# Altium schematic sheet SCH_HT-CT62.SchDoc
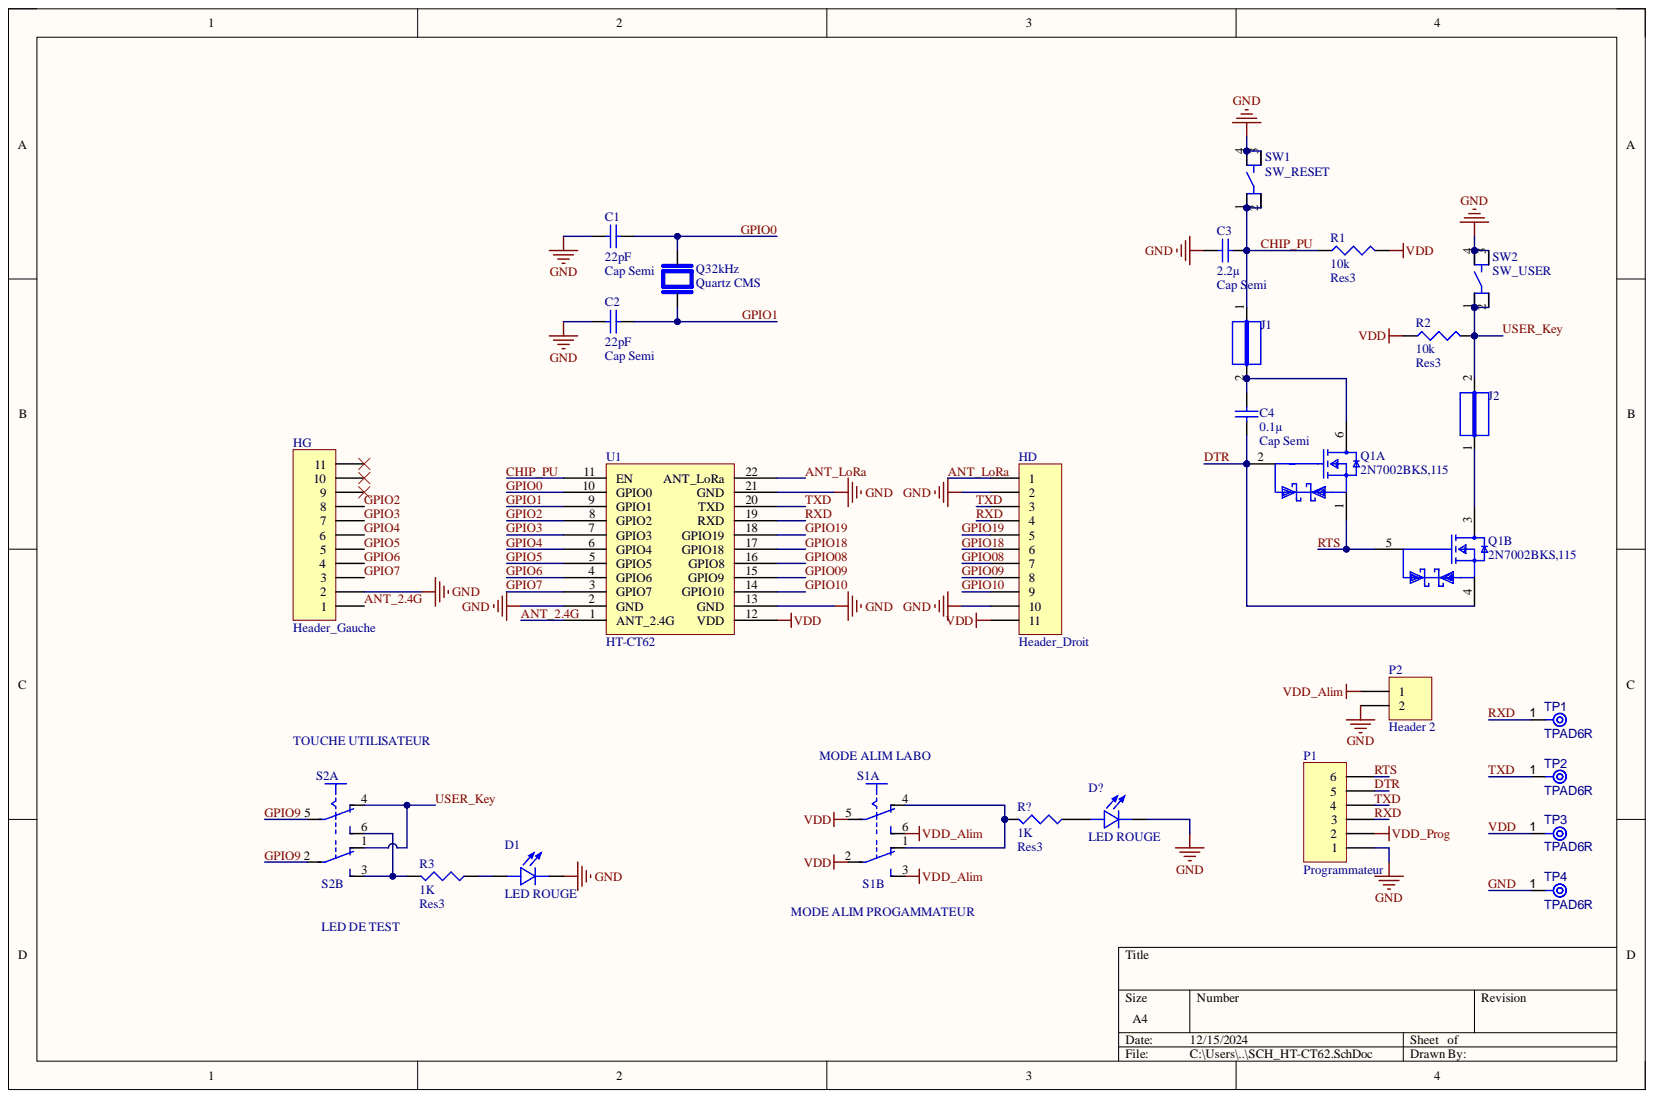
[diagram p0: SCH_HT-CT62.SchDoc]

## Parts
C1  Cap Semi  value 22pF  [1608[0603]]
C2  Cap Semi  value 22pF  [1608[0603]]
C3  Cap Semi  value 2.2µ  [1608[0603]]
C4  Cap Semi  value 0.1µ  [1608[0603]]
D1  LED ROUGE  [LED_KP-1608SURCK]
D?  LED ROUGE  [LED_KP-1608SURCK]
HD  Header_Droit  [HDR1X11]
HG  Header_Gauche  [HDR1X11]
J1  JUMPER  [JUMPER]  (value not drawn)
J2  JUMPER  [JUMPER]  (value not drawn)
P1  Programmateur  [HDR1X6]
P2  Header 2  [HDR1X2]
Q1  2N7002BKS,115  [FP-SOT363-IPC_C]  (parts A,B)
Q32kHz  Quartz CMS  [XTAL_ABS07-32.768KHZ-6-T]
R1  Res3  value 10k  [J1-0603]
R2  Res3  value 10k  [J1-0603]
R3  Res3  value 1K  [J1-0603]
R?  Res3  value 1K  [J1-0603]
S1  MODE ALIM LABO  [JS202011CQN]  (parts A,B)
S2  TOUCHE UTILISATEUR  [JS202011CQN]  (parts A,B)
SW1  SW_RESET  [SW_PTS810_SJM_250_SMTR_LFS]
SW2  SW_USER  [SW_PTS810_SJM_250_SMTR_LFS]
TP1  TPAD6R  [TP06R]  (no BOM)
TP2  TPAD6R  [TP06R]  (no BOM)
TP3  TPAD6R  [TP06R]  (no BOM)
TP4  TPAD6R  [TP06R]  (no BOM)
U1  HT-CT62  [HTCC-AM01]

## Nets
VDD_Alim: P2.1 S1.3 S1.6
VDD_Prog: P1.2
ANT_2.4G: HG.1 U1.1(ANT_2.4G)
ANT_LoRa: HD.1 U1.22(ANT_LoRa)
CHIP_PU: C3.2 J1.1 R1.1 SW1.1 SW1.2 U1.11(EN)
DTR: C4.1 P1.5 Q1.2 Q1.4
GND: C1.1 C2.1 C3.1 D1.2 D?.2 HD.2 HD.10 HG.2 P1.1 P2.2 SW1.3 SW1.4 SW2.3 SW2.4 TP4.1 U1.2(GND) U1.13(GND) U1.21(GND)
GPIO0: C1.2 Q32kHz.2 U1.10(GPIO0)
GPIO1: C2.2 Q32kHz.1 U1.9(GPIO1)
GPIO2: HG.8 U1.8(GPIO2)
GPIO3: HG.7 U1.7(GPIO3)
GPIO4: HG.6 U1.6(GPIO4)
GPIO5: HG.5 U1.5(GPIO5)
GPIO6: HG.4 U1.4(GPIO6)
GPIO7: HG.3 U1.3(GPIO7)
GPIO08: HD.7 U1.16(GPIO8)
GPIO9: HD.8 S2.2 S2.5 U1.15(GPIO9)  [also labelled GPIO09]
GPIO10: HD.9 U1.14(GPIO10)
GPIO18: HD.6 U1.17(GPIO18)
GPIO19: HD.5 U1.18(GPIO19)
RTS: P1.6 Q1.1 Q1.5
RXD: HD.4 P1.3 TP1.1 U1.19(RXD)
TXD: HD.3 P1.4 TP2.1 U1.20(TXD)
USER_Key: J2.2 R2.2 S2.1 S2.4 SW2.1 SW2.2
VDD: HD.11 R1.2 R2.1 S1.2 S1.5 TP3.1 U1.12(VDD)
NetC4_2: C4.2 J1.2 Q1.6
NetD1_1: D1.1 R3.1
NetD?_1: D?.1 R?.1
NetJ2_1: J2.1 Q1.3
NetR3_2: R3.2 S2.3 S2.6
NetR?_2: R?.2 S1.1 S1.4

Not connected: HG 9 10 11

## Library data of the parts
C1-C4 = Cap Semi: Capacitor (Semiconductor SIM Model); Component Kind: Standard; LatestRevisionDate: 29-May-2009; LatestRevisionNote: IPC-7351 Footprint Added.; Library Name: Miscellaneous Devices.IntLib; PackageDescription: Chip Capacitor; PackageReference: C1206; Published: 8-Jun-2000; Signal Integrity: Cap Semi; Simulation: CAP; Publisher: Altium Limited
D1 D? = KP-1608SURCK: LED; SMD; 0603; red; 50-150mcd; 1.6x0.8mm; 120Â°; 20mA;; MF: Kingbright; Check_prices: https://www.snapeda.com/parts/KP-1608SURCK/Kingbright/view-part/?ref=eda; Component Kind: Standard; Library Name: KP-1608SURCK.IntLib; MP: KP-1608SURCK; Package: 0603 Kingbright; SnapEDA_Link: https://www.snapeda.com/parts/KP-1608SURCK/Kingbright/view-part/?ref=snap
HD HG = Header 11: Header, 11-Pin; Component Kind: Standard; LatestRevisionDate: 17-Jul-2002; LatestRevisionNote: Re-released for DXP Platform.; Library Name: Miscellaneous Connectors.IntLib; Signal Integrity: Connector; Publisher: Altium Limited
J1 J2 = CMP-009-00061-2: Component Kind: Standard; Description: JUMPER; Pins: 2; Library Name: Titouan Lasquellec
P1 = Header 6: Header, 6-Pin; Component Kind: Standard; LatestRevisionDate: 17-Jul-2002; LatestRevisionNote: Re-released for DXP Platform.; Library Name: Miscellaneous Connectors.IntLib; Signal Integrity: Connector; Publisher: Altium Limited
P2 = Header 2: Header, 2-Pin; Component Kind: Standard; LatestRevisionDate: 17-Jul-2002; LatestRevisionNote: Re-released for DXP Platform.; Library Name: Miscellaneous Connectors.IntLib; Signal Integrity: Connector; Publisher: Altium Limited
Q1 = CMP-00000-1: Small Signal Field-Effect Transistor, 0.3A I(D), 60V, 2-Element, N-Channel, Silicon, Metal-oxide Semiconductor FET; Drain Current-Max (ID): 300mA; Drain-source On Resistance-Max: 1.6R; Number of Elements: 2; Operating Mode: ENHANCEMENT MODE; Package Body Material: Plastic; Reference Standard: AEC-Q101; Transistor Application: SWITCHING; Component Kind: Standard; DS Breakdown Voltage-Min: 60V; FET Technology: METAL-OXIDE SEMICONDUCTOR; JESD-30 Code: R-PDSO-G6; JESD-609 Code: e3; Moisture Sensitivity Level: 1; Package Shape: Rectangular; Package Style: SMALL OUTLINEMeter; Pins: 6; Polarity/Channel Type: N-CHANNEL; Reach SVHC Compliant: Yes; RoHS Compliant: Yes; Surface Mount: Yes; Terminal Finish: Tin; Terminal Form: Gull Wing; Terminal Position: DUAL; Transistor Element Material: SILICON; Library Name: Titouan Lasquellec
Q32kHz = ABS07-32.768KHZ-6-T: 32.768 kHz Â±20ppm Crystal 6pF 70 kOhms 2-SMD, No Lead; MF: Abracon; Check_prices: https://www.snapeda.com/parts/ABS07-32.768KHZ-6-T/Abracon+LLC/view-part/?ref=eda; Component Kind: Standard; Digi-Key_Part_Number: 535-11898-2-ND; Library Name: ABS07-32.768KHZ-6-T.IntLib; MP: ABS07-32.768KHZ-6-T; Package: SMD-2 Abracon LLC; Purchase-URL: https://pricing.snapeda.com/search/part/ABS07-32.768KHZ-6-T/?ref=eda; SnapEDA_Link: https://www.snapeda.com/parts/ABS07-32.768KHZ-6-T/Abracon+LLC/view-part/?ref=snap
R1-R3 R? = Res3: Resistor; Component Kind: Standard; LatestRevisionDate: 29-May-2009; LatestRevisionNote: IPC-7351 Footprint Added.; Library Name: Miscellaneous Devices.IntLib; PackageDescription: Chip Resistor; PackageReference: J1-0603; Published: 8-Jun-2000; Signal Integrity: Res; Simulation: RESISTOR; Publisher: Altium Limited
S1 S2 = JS202011CQN: Slide Switch DPDT Through Hole; MF: C&K; Availability: Unavailable; Component Kind: Standard; Library Name: JS202011CQN.IntLib; MP: JS202011CQN; Package: None; Price: None; SnapEDA_Link: https://www.snapeda.com/parts/JS202011CQN/C%26K/view-part/184394/?ref=snap
SW1 SW2 = CMP-011-00014-1: Tactile Switch SPST-NO Top Actuated Surface Mount; MF: C&K; MP: PTS810 SJM 250 SMTR LFS; Case/Package: None; Check_prices: https://www.snapeda.com/parts/PTS810%20SJM%20250%20SMTR%20LFS/C%2526K/view-part/?ref=eda; Component Kind: Standard; Component Type: Standard; Design Item ID: PTS810_SJM_250_SMTR_LFS; Pins: 4; SnapEDA_Link: https://www.snapeda.com/parts/PTS810%20SJM%20250%20SMTR%20LFS/C%2526K/view-part/?ref=snap; Source: PTS810_SJM_250_SMTR_LFS.SchLib; Library Name: Titouan Lasquellec
TP1-TP4 = CMP-00028-00002-2: 0.6mm round; Pins: 1; Library Name: Projects_Baptiste_TAANE
U1 = HT-CT62: Component Kind: Standard; Value: HT-CT62; Library Name: HeltecModule.SCHLIB
